# Altium schematic sheet Main.SchDoc(Main)
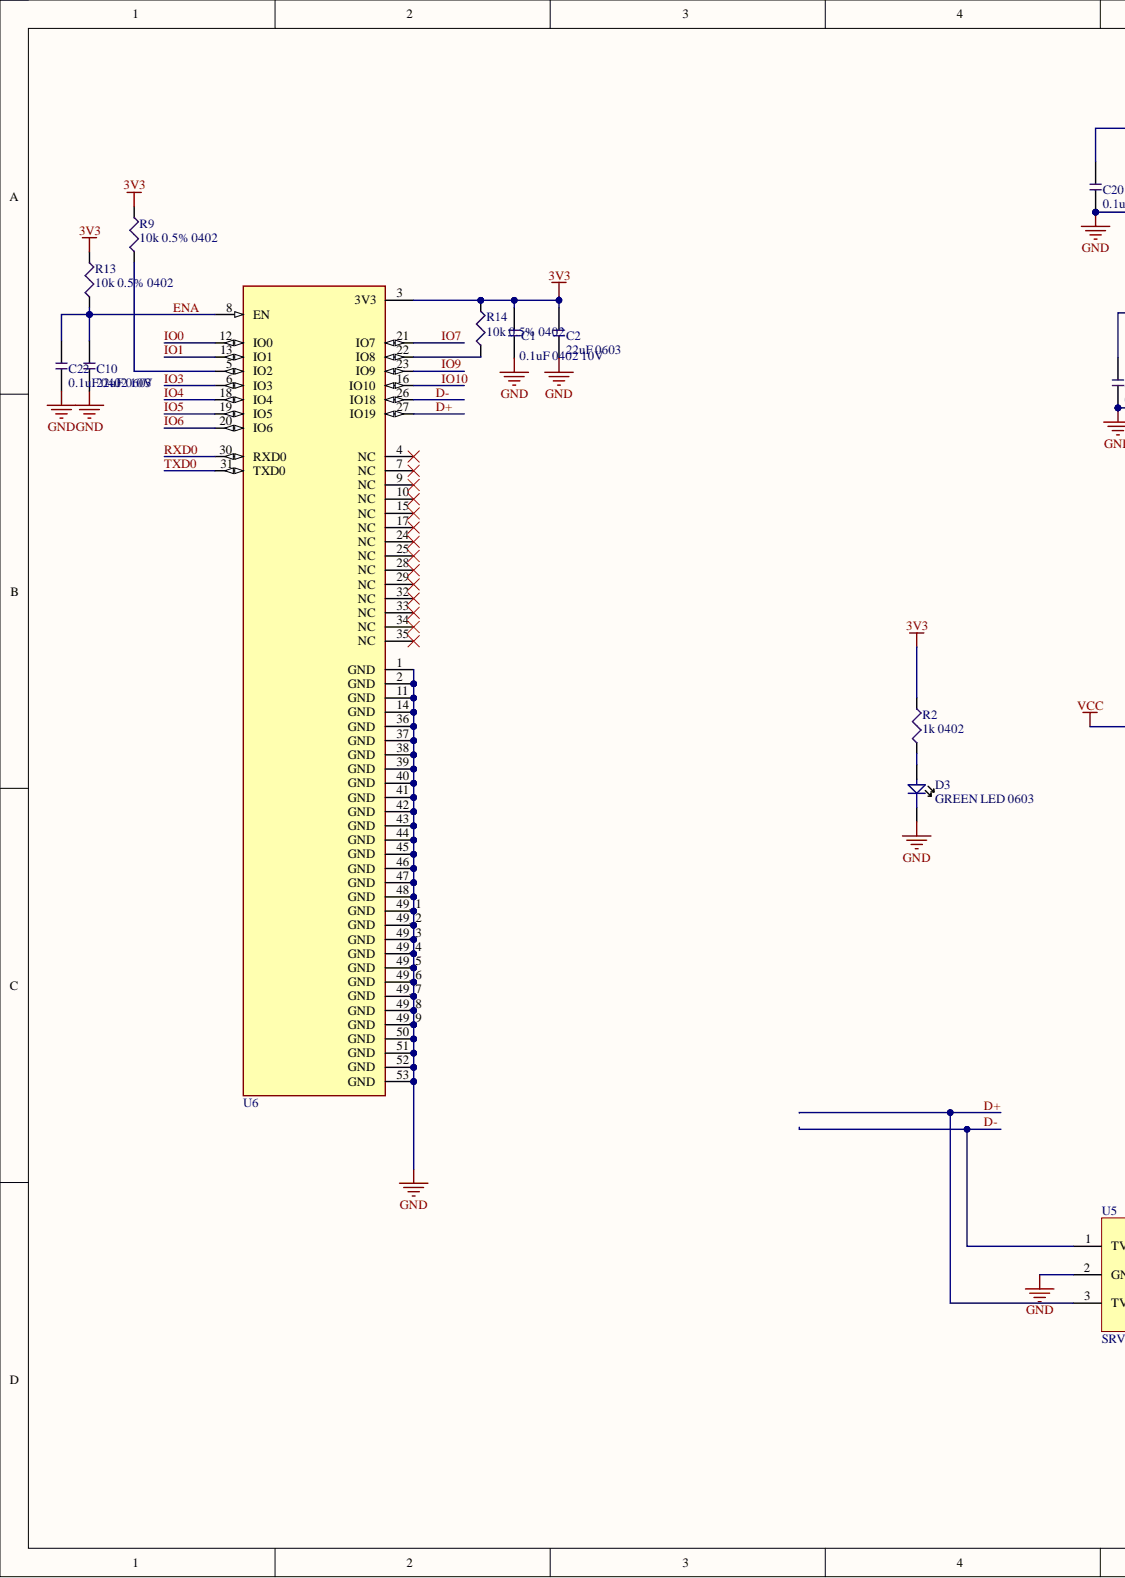
[diagram p0: Main.SchDoc, left]
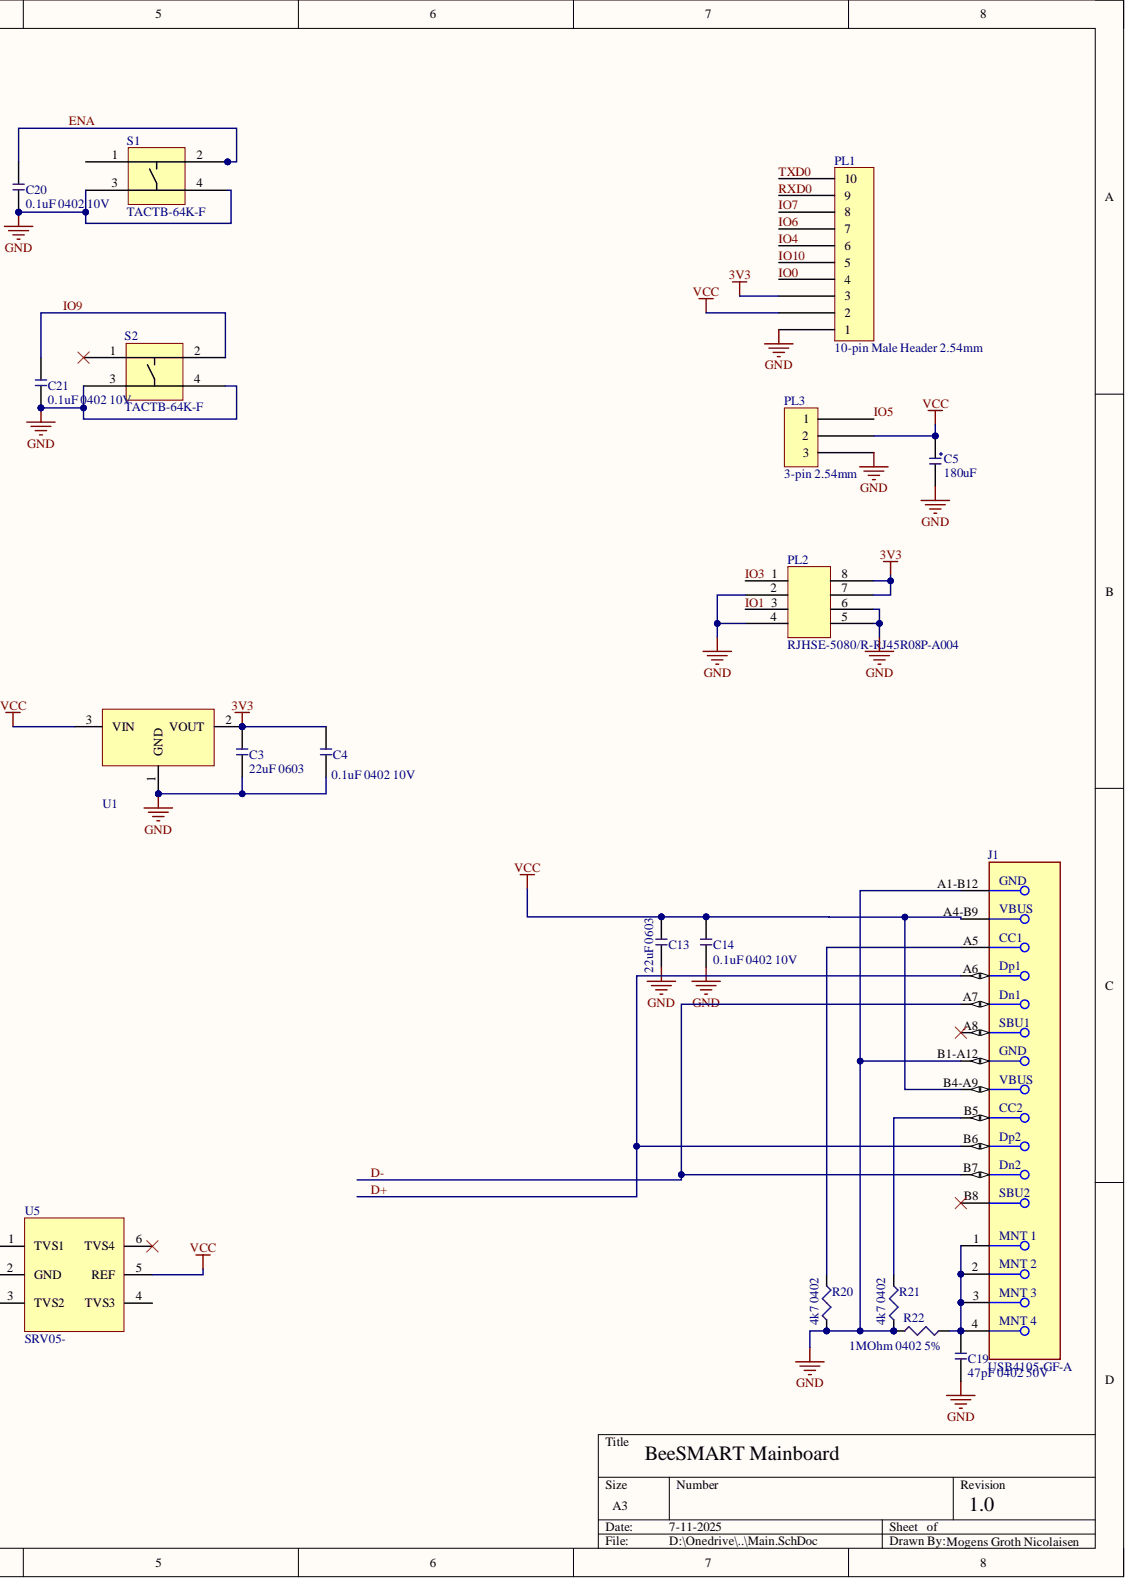
[diagram p1: Main.SchDoc, right]

## Parts
C1  0.1uF 0402 10V  [CAP_0402]
C2  22uF 0603  value 22uF  Capacitance: 22uF  Case Code (Imperial): 0603  [CAP_0603]
C3  22uF 0603  value 22uF  Capacitance: 22uF  [CAP_0603]
C4  0.1uF 0402 10V  [CAP_0402]
C5  180uF  [Capacitor 8x22]
C10  22uF 0603  value 22uF  Capacitance: 22uF  Case Code (Imperial): 0603  [CAP_0603]
C13  22uF 0603  value 22uF  Capacitance: 22uF  Case Code (Imperial): 0603  [CAP_0603]
C14  0.1uF 0402 10V  [CAP_0402]
C19  47pF 0402 50V  value 47pF  [CAP_0402]
C20  0.1uF 0402 10V  [CAP_0402]
C21  0.1uF 0402 10V  [CAP_0402]
C22  0.1uF 0402 10V  [CAP_0402]
D3  GREEN LED 0603  [0603 LED]
J1  USB4105-GF-A  [FP-USB4105-GF-A-MFG]
PL1  10-pin Male Header 2.54mm  [10-pin 2.54mm header]
PL2  RJHSE-5080/R-RJ45R08P-A004  [AMPHENOL RJHSE-5080]
PL3  3-pin 2.54mm  [3-pin 2.54mm header]
R2  1k 0402  value 1k  Case/Package: 0402  [RES_0402]
R9  10k 0.5% 0402  value 10k  Case/Package: 0402  Tolerance: 0.5%  [RES_0402]
R13  10k 0.5% 0402  value 10k  Tolerance: 0.5%  [RES_0402]
R14  10k 0.5% 0402  value 10k  [RES_0402]
R20  4k7 0402  value 4k7  Case/Package: 0402  [RES_0402]
R21  4k7 0402  value 4k7  Case/Package: 0402  [RES_0402]
R22  1MOhm 0402 5%  Case/Package: 0402  Tolerance: 5%  [RES_0402]
S1  TACTB-64K-F  [TACTB-64K-F]
S2  TACTB-64K-F  [TACTB-64K-F]
U1  3.3V LDO 1A  [SOT230P700X180-4N]  (value not drawn)
U5  SRV05-  [SOT95P280X145-6N]
U6  ESP32-C3-MINI-1-N4  [ESP32-C3-MINI-1-N4-Footprint-1]  (value not drawn)

## Nets
3V3: C1.2 C2.2 C3.2 C4.2 PL1.3 PL2.7 PL2.8 R2.2 R9.2 R13.2 R14.2 U1.2 U6.3(3V3)
GND: C1.1 C2.1 C3.1 C4.1 C5.2 C10.1 C13.1 C14.1 C19.1 C20.1 C21.1 C22.2 D3.2 J1.A1-B12 J1.B1-A12 PL1.1 PL2.2 PL2.4 PL2.5 PL2.6 PL3.3 R20.1 R21.1 R22.1 S1.3 S1.4 S2.3 S2.4 U1.1 U5.2(GND) U6.1(GND) U6.2(GND) U6.11(GND) U6.14(GND) U6.36(GND) U6.37(GND) U6.38(GND) U6.39(GND) U6.40(GND) U6.41(GND) U6.42(GND) U6.43(GND) U6.44(GND) U6.45(GND) U6.46(GND) U6.47(GND) U6.48(GND) U6.49_1(GND) U6.49_2(GND) U6.49_3(GND) U6.49_4(GND) U6.49_5(GND) U6.49_6(GND) U6.49_7(GND) U6.49_8(GND) U6.49_9(GND) U6.50(GND) U6.51(GND) U6.52(GND) U6.53(GND)
VCC: C5.1 C13.2 C14.2 J1.A4-B9 J1.B4-A9 PL1.2 PL3.2 U1.3 U5.5(REF)
D+: J1.A6 J1.B6 U5.3(TVS2) U6.27(IO19)
D-: J1.A7 J1.B7 U5.1(TVS1) U6.26(IO18)
ENA: C10.2 C20.2 C22.1 R13.1 S1.2 U6.8(EN)
IO0: PL1.4 U6.12(IO0)
IO1: PL2.3 U6.13(IO1)
IO3: PL2.1 U6.6(IO3)
IO4: PL1.6 U6.18(IO4)
IO5: PL3.1 U6.19(IO5)
IO6: PL1.7 U6.20(IO6)
IO7: PL1.8 U6.21(IO7)
IO9: C21.2 S2.2 U6.23(IO9)
IO10: PL1.5 U6.16(IO10)
RXD0: PL1.9 U6.30(RXD0)
TXD0: PL1.10 U6.31(TXD0)
NetC19_2: C19.2 J1.1 J1.2 J1.3 J1.4 R22.2
NetD3_1: D3.1 R2.1
NetJ1_A5: J1.A5 R20.2
NetJ1_B5: J1.B5 R21.2
NetR9_1: R9.1 U6.5(IO2)
NetR14_1: R14.1 U6.22(IO8)

Not connected: J1 A8 B8; S1 1; S2 1; U5 4(TVS3) 6(TVS4); U6 4(NC) 7(NC) 9(NC) 10(NC) 15(NC) 17(NC) 24(NC) 25(NC) 28(NC) 29(NC) 32(NC) 33(NC) 34(NC) 35(NC)

## Library data of the parts
C1 C4 C14 C20-C22 = CMP-00101-1: 0.1uF 0402 10V X7R 10%; Value: 100 nF; Component Kind: Standard; Library Name: ON Development Vault; Tolerance: 10 %; Voltage: 10 V
C2 C3 C10 C13 = CMP-00148-1: Cap Ceramic 22uF 6.3V X5R 20% SMD 0603 85C Cardboard T/R; Case Code (Metric): 1608; Case/Package: 0603; Component Kind: Standard; Construction: Flat; Contact Plating: Tin; Depth: 0.8mm; Dielectric: X5R; Height: 0.8mm; Length: 1.6mm; Library Name: ON Development Vault; Material: Ceramic; Max Operating Temperature: 85°C; Min Operating Temperature: -55°C; Mount: Surface Mount; Package Quantity: 1; Packaging: Tape and Reel; Radiation Hardening: No; RoHS Compliant: Yes; Series: CL; Thickness: 0.039inch; Tolerance: 20%; Voltage: 6.3V; Voltage Rating: 6.3V; Width: 0.031inch
  C3: Case Code (Imperial): 0603
C5 = CMP-002-0002-4: CAP ALUM 180UF 20% 50V RADIAL; Mfg. Part Number: EEU-FR1H181LB; Case/Package: -; Component Kind: Standard; Library Name: ON Development Vault; Tolerance: 20%; Value: 180uF; Voltage: 50V
C19 = CMP-00099-1: 47pF 0402 50V X7R 5%; Tolerance: 10%; Component Kind: Standard; Library Name: ON Development Vault; Voltage: 50V
D3 = CMP-008-0000-1: SMD GREEN WATER CLEAR; Mfg. Part Number: APT1608SGC; Component Kind: Standard; Library Name: ON Development Vault
J1 = CMP-003-0034-0.0: Conn USB 2.0 Type C RCP 24 POS 0.3mm/0.5mm Solder RA SMD 24 Terminal 1 Port T/R; Gender: Receptacle; Mating Cycles: 10000; Number of Ports: 1; Shielding: Shielded; Component Kind: Standard; Connector Type: USB; Contact Plating: Gold; Flammability Rating: UL94 V-0; Insulation Color: Black; Library Name: ON Development Vault; Max Operating Temperature: 85°C; Min Operating Temperature: -40°C; Mount: Surface Mount,Through Hole,Horizontal; Series: USB4105; Termination: Solder
PL1 = CMP-003-0020-0.0: 10 Positions Header Connector 0.100" (2.54mm) Through Hole Gold; Component Kind: Standard; Library Name: ON Development Vault
PL2 = CMP-003-0022-0.1: CONN MOD JACK 8P8C R/A UNSHLD; Connector Type: Jack, RJ45; Contact Resistance: 20mR; Current Rating: 1.5A; Direction: Up; Gender: Female; Max Voltage Rating (AC): 125V; Number of Contacts: 8; Number of Ports: 1; Pitch: 2.03mm; Shielding: Unshielded; Colour: Black; Component Kind: Standard; Contact Material: Bronze; Contact Plating: Gold; Depth: 14.99mm; Flammability Rating: UL94 V-0; Housing Material: Thermoplastic; Lead Free: Lead Free; Length: 15.75mm; Library Name: ON Development Vault; Max Current Rating: 1.5A; Max Operating Temperature: 85°C; Min Operating Temperature: -55°C; Mount: Panel, Through Hole; Number of Positions: 8; Number of Positions Loaded: 8; Number of Rows: 1; Orientation: Right Angle; Package Quantity: 36; Packaging: Tray; Radiation Hardening: No; REACH SVHC: Unknown; Termination: Solder; Voltage Rating: 125V; Voltage Rating (DC): 125V
PL3 = CMP-003-0018-1: Pin header 3-pin 2.54mm male; Mfg. Part Number: DS1021-1*3SF1-1; Cat: Connectors; DynamicData: Ningbo Connfly Electric DS1021-1*3SF1-1; LatestRevision: 1; Component Kind: Standard; FootprintName1: 3-pin 2.54mm header; FootprintRevisionID1: PCC-0050-2; Library Name: ON Development Vault
R2 = CMP-001-0025-3: RES SMD 1K OHM 1% 1/16W 0402; Mfg. Part Number: CRG0402F1K0; Component Kind: Standard; Library Name: ON Development Vault; Power: 1/16W; Tolerance: 1%
R9 R13 R14 = CMP-0001-00029-2: RES 10K OHM 0.5% 1/16W 0402; Manufacturer Part No.: RC0402DR-0710KL; Component Kind: Standard; Library Name: ON Development Vault
  R13: Case/Package: 0402
  R14: Case/Package: 0402; Tolerance: 0.5%
R20 R21 = CMP-001-0029-3: RES SMD 4.7K OHM 1% 1/16W 0402; Mfg. Part Number: RC1005F472CS; Tolerance: 1; Component Kind: Standard; Library Name: ON Development Vault; Power: 1/16W
R22 = CMP-001-0065-1: RES SMD 1M OHM 5% 1/16W 0402; Mfg. Part Number: CR0402JC1MS001; Value: 1Mega; Component Kind: Standard; Library Name: ON Development Vault; Power: 1/16 W
S1 S2 = CMP-013-0002-1: Microswitch TACT; SPST-NO; Positions:2; 0.05A/12VDC;; Case/Package: -; Component Kind: Standard; Library Name: ON Development Vault; Mfg. Part No.: TACTB-64K-F; Mount: SMD; Pins: 4; RoHS: Yes
U1 = CMP-00114-2: IC REG LINEAR 3.3V 1A SOT223; Accuracy: 1%; Dropout Voltage: 1.1V; Max Input Voltage: 13V; Number of Outputs: 1; Operating Supply Current: 3.5mA; Output Type: Fixed; Polarity: Positive; Power Supply Rejection Ratio (PSRR): 70dB; Reference Voltage: 1.262V; Case/Package: TO-261-4; Component Kind: Standard; Contact Plating: Tin; Lead Free: Lead Free; Library Name: ON Development Vault; Max Operating Temperature: 125°C; Max Output Current: 1A; Min Operating Temperature: -40°C; Mount: Surface Mount; Number of Pins: 3; Number of Regulators: 1; Output Current: 1A; Output Voltage: 3.3V; Package Quantity: 4000; Packaging: Tape and Reel; Quiescent Current: 3.5mA; Weight: 0.000282oz
U5 = CMP-016-0020-1: SRV05 Series 4 Ch 3.5 pF 15 Vclamp Steering Diode/TVS Array Combo - SOT-23-6; Capacitance: 3.5pF; Clamping Voltage: 15V; Direction: Unidirectional; Leakage Current: 5uA; Number of Channels: 4; Number of Elements: 1; Peak Pulse Current: 5A; Peak Pulse Power: 500W; Reverse Breakdown Voltage: 6V; Reverse Standoff Voltage: 5V; Test Current: 1mA; Case/Package: SOT-23; Component Kind: Standard; Depth: 1.75mm; Lead Free: Lead Free; Length: 3.05mm; Library Name: ON Development Vault; Max Operating Temperature: 150°C; Min Operating Temperature: -55°C; Mount: Surface Mount; Number of Pins: 6; Packaging: Tape and Reel; Polarity: Unidirectional; Radiation Hardening: No; RoHS: Yes; RoHS Compliant: Yes; Working Voltage: 5V
U6 = CMP-00162-1: Bluetooth, WiFi 802.11b/g/n, Bluetooth v5.0 Transceiver Module 2.412GHz ~ 2.484GHz PCB Trace Surface Mount; Component Kind: Standard; Library Name: ON Development Vault; Manufacturer Part No.: ESP32-C3-MINI-1-N4
